# Altium schematic sheet SH_DIM_V1.0.SchDot
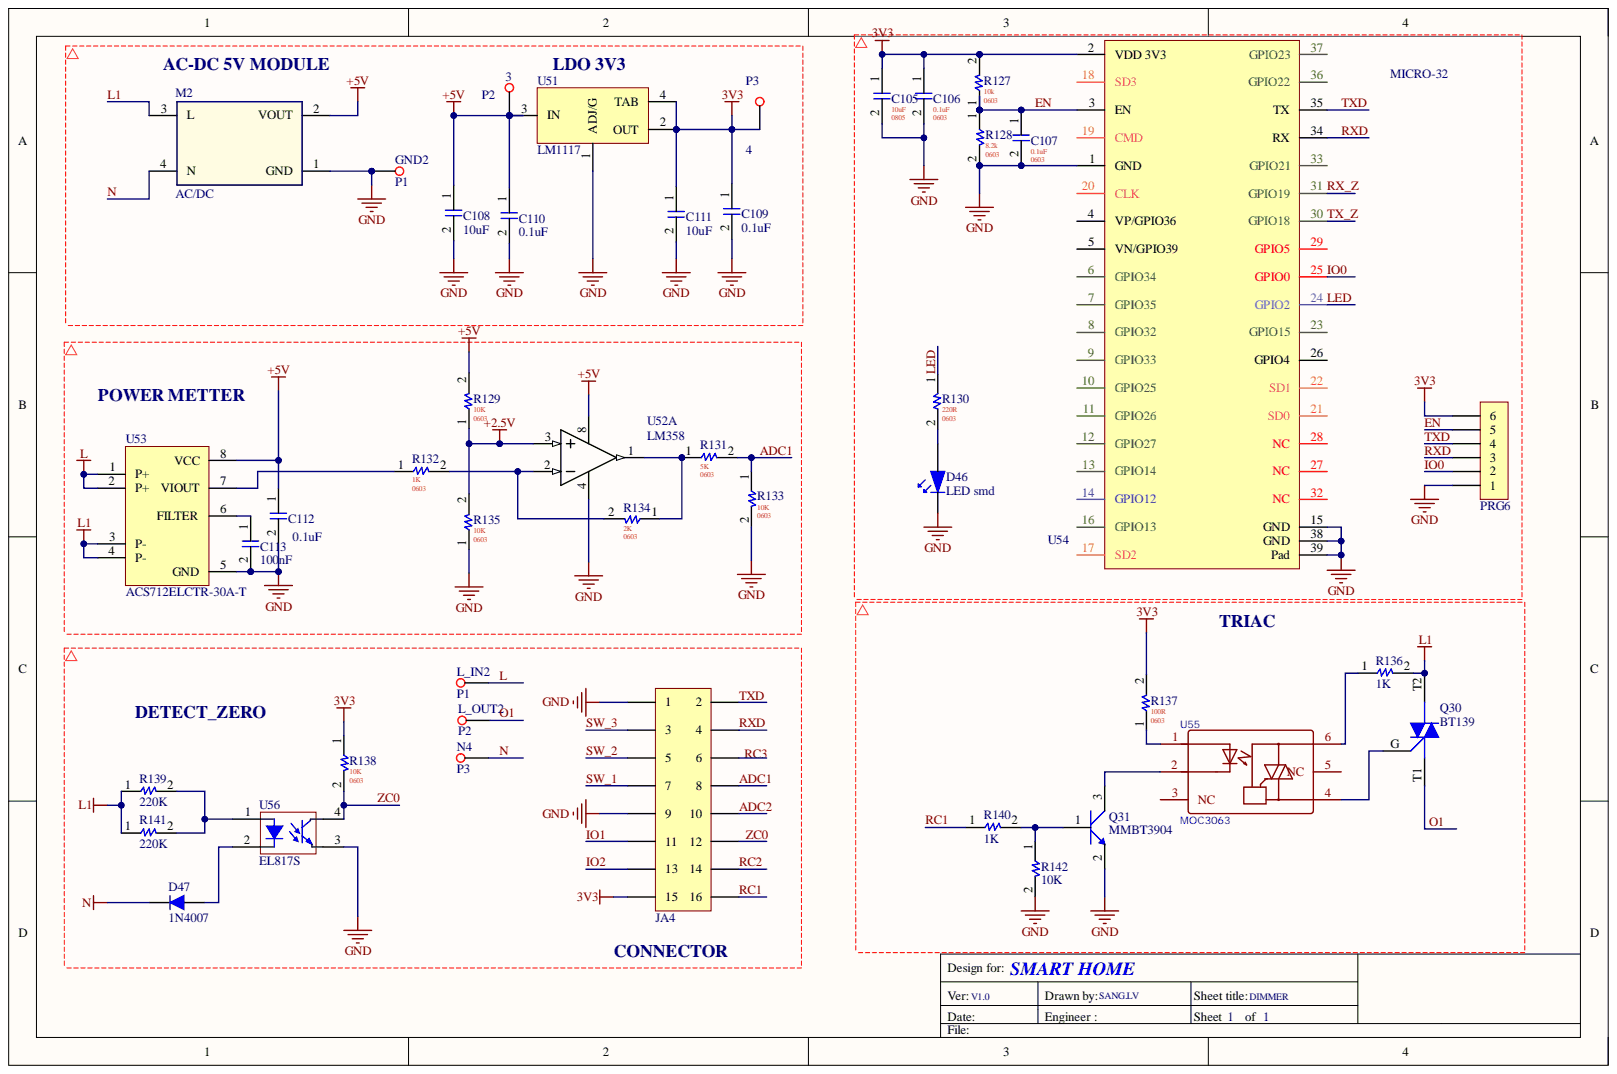
[diagram p0: SH_DIM_V1.0.SchDot]

## Parts
3  P2  [TEXT_POINT]
4  P3  [TEXT_POINT]
C105  10uF  FP: 0805  [0805 C]
C106  0.1uF  FP: 0603  [0603 C]
C107  0.1uF  FP: 0603  [0603 C]
C108  10uF  [0805 C]
C109  0.1uF  [0603 C]
C110  0.1uF  [0603 C]
C111  10uF  [0805 C]
C112  0.1uF  [0603 C]
C113  100nF  [0603 C]
D46  LED smd  [LED0603_1608]
D47  1N4007  [SOD-323]
GND2  P1  [TEXT_POINT]
JA4  rao doi  [T]  (value not drawn)
L_IN2  P1  [P1]
L_OUT2  P2  [P1]
M2  AC/DC  [AC-DC 5V K4H24]
N4  P3  [P1]
PRG6  P6  [P6 - 1.27MM]  (value not drawn)
Q30  BT139  [TO-220]
Q31  MMBT3904  [SOT 23-3]
R127  10k  FP: 0603  [0603 R]
R128  8.2k  FP: 0603  [0603 R]
R129  value 10K  FP: 0603  [0603 R]  (hidden comment: 5K1)
R130  value 220R  FP: 0603  [0603 R]  (hidden comment: 10k)
R131  5K  FP: 0603  [0603 R]
R132  1K  FP: 0603  [0603 R]
R133  10K  FP: 0603  [0603 R]
R134  2K  FP: 0603  [0603 R]
R135  10K  FP: 0603  [0603 R]
R136  1K  [1206 R]
R137  100R  FP: 0603  [0603 R]
R138  value 10K  FP: 0603  [0603 R]  (hidden comment: 1.5K)
R139  220K  [1206 R]
R140  1K  [0603 R]
R141  220K  [1206 R]
R142  10K  [0603 R]
U51  LM1117  [SOT23-4]
U52  LM358  [SOIC 8]  (part A)
U53  ACS712ELCTR-30A-T  [SOIC 8]
U54  MICRO-32  [ESP MICRO 32]
U55  MOC3063  [TO252]
U56  EL817S  [EL357N]

## Nets
3V3: 4.1 C105.1 C106.1 C109.1 C111.1 JA4.15 PRG6.6 R127.2 R137.2 R138.1 U51.2(OUT) U51.4(TAB) U54.2(VDD_3V3)
+2.5V: R129.1 R135.2 U52.3
+5V: 3.1 C108.1 C110.1 C112.1 M2.2(VOUT) R129.2 U51.3(IN) U52.8 U53.8(VCC)
GND: C105.2 C106.2 C107.2 C108.2 C109.2 C110.2 C111.2 C112.2 C113.2 D46.2 GND2.1 JA4.1 JA4.9 M2.1(GND) PRG6.1 Q31.2 R128.2 R133.2 R135.1 R142.2 U51.1(ADJ/G) U52.4 U53.5(GND) U54.1(GND) U54.15(GND) U54.38(GND) U54.39(Pad) U56.3
ADC1: JA4.8 R131.2 R133.1
ADC2: JA4.10
EN: C107.1 PRG6.5 R127.1 R128.1 U54.3(EN)
IO0: PRG6.2 U54.25(GPIO0)
IO1: JA4.11
IO2: JA4.13
L: L_IN2.1 U53.1(P+) U53.2(P+)
L1: M2.3(L) Q30.T2 R136.2 R139.1 R141.1 U53.3(P-) U53.4(P-)
LED: R130.1 U54.24(GPIO2)
N: D47.2 M2.4(N) N4.1
O1: L_OUT2.1 Q30.T1
RC1: JA4.16 R140.1
RC2: JA4.14
RX_Z: U54.31(GPIO19)
RXD: JA4.4 PRG6.3 U54.34(RX)
SW_1: JA4.7
SW_2: JA4.5
SW_3: JA4.3
TX_Z: U54.30(GPIO18)
TXD: JA4.2 PRG6.4 U54.35(TX)
ZC0: JA4.12 R138.2 U56.4
NetC113_1: C113.1 U53.6(FILTER)
NetD46_1: D46.1 R130.2
NetD47_1: D47.1 U56.2
NetQ30_G: Q30.G U55.4
NetQ31_1: Q31.1 R140.2 R142.1
NetQ31_3: Q31.3 U55.2
NetR131_1: R131.1 R134.1 U52.1
NetR132_1: R132.1 U53.7(VIOUT)
NetR132_2: R132.2 R134.2 U52.2
NetR136_1: R136.1 U55.6
NetR137_1: R137.1 U55.1
NetR139_2: R139.2 R141.2 U56.1
RC3:

Not connected: JA4 6; U54 4(VP/GPIO36) 5(VN/GPIO39) 6(GPIO34) 7(GPIO35) 8(GPIO32) 9(GPIO33) 10(GPIO25) 11(GPIO26) 12(GPIO27) 13(GPIO14) 14(GPIO12) 16(GPIO13) 17(SD2) 18(SD3) 19(CMD) 20(CLK) 21(SD0) 22(SD1) 23(GPIO15) 26(GPIO4) 27(NC) 28(NC) 29(GPIO5) 32(NC) 33(GPIO21) 36(GPIO22) 37(GPIO23); U55 3(NC) 5(NC)

## Library data of the parts
3 4 L_OUT2 N4 = P1: Component Kind: Standard; Library Name: Kienlib.SchLib
C105 = 0805 10uF CER: CAP CER 10uF 50V 10% 0805 SMD; VOLTAGE + TOLE: 50V 10%; Component Kind: Standard; ComponentLink1Description: New link; DATE: 04-Mar-21; Library Name: Capacitor.SchLib; PACKAGE: SMD; VALUE: 10uF; CREATOR: MMUOP
C106 C107 = 0603 0.1uF CER: CAP CER 0.1uF 50V 10% 0603 SMD; VOLTAGE + TOLE: 50V 10%; Component Kind: Standard; ComponentLink1Description: New link; DATE: 04-Mar-21; Library Name: Capacitor.SchLib; PACKAGE: SMD; VALUE: 0.1uF; CREATOR: MMUOP
C108-C113 = CAP NOP: Component Kind: Standard; Library Name: Kienlib.SchLib
D47 = Diode schottky: Component Kind: Standard; Library Name: Kienlib.SchLib
JA4 = P2x8: Component Kind: Standard; Library Name: Kienlib.SchLib
Q30 = TRIAC: Component Kind: Standard; Library Name: Kienlib.SchLib
Q31 = TRANS NPN: Component Kind: Standard; Library Name: Kienlib.SchLib
R127 R138 = 0603 10k 1%: 10k 1% 0603 SMD; PN: C98220; Component Kind: Standard; ComponentLink1Description: New link; DATE: 04-Mar-21; Library Name: Res.SchLib; PACKAGE: SMD; VOLTAGE + TOLE: 1%; CREATOR: MMUOP
  R127: VALUE: 10k
R128 = 0603 8.2k 1%: 8.2k 1% 0603 SMD; Component Kind: Standard; ComponentLink1Description: New link; DATE: 04-Mar-21; Library Name: Res.SchLib; PACKAGE: SMD; VALUE: 8.2k; VOLTAGE + TOLE: 1%; CREATOR: MMUOP
R129 = 0603 10k 1%: 10K 1% 0603 SMD; PN: C98220; Component Kind: Standard; ComponentLink1Description: New link; DATE: 04-Mar-21; Library Name: Res.SchLib; PACKAGE: SMD; VOLTAGE + TOLE: 1%; CREATOR: MMUOP
R130 = 0603 10k 1%: 220R 1% 0603 SMD; PN: C98220; Component Kind: Standard; ComponentLink1Description: New link; DATE: 04-Mar-21; Library Name: Res.SchLib; PACKAGE: SMD; VOLTAGE + TOLE: 1%; CREATOR: MMUOP
R131 = 0603 100k 1%: 5K 1% 0603 SMD; Component Kind: Standard; ComponentLink1Description: New link; DATE: 04-Mar-21; Library Name: Res.SchLib; PACKAGE: SMD; VALUE: 5K; VOLTAGE + TOLE: 1%; CREATOR: MMUOP
R132 = 0603 100k 1%: 1K 1% 0603 SMD; Component Kind: Standard; ComponentLink1Description: New link; DATE: 04-Mar-21; Library Name: Res.SchLib; PACKAGE: SMD; VALUE: 1K; VOLTAGE + TOLE: 1%; CREATOR: MMUOP
R133 = 0603 100k 1%: 10K 1% 0603 SMD; Component Kind: Standard; ComponentLink1Description: New link; DATE: 04-Mar-21; Library Name: Res.SchLib; PACKAGE: SMD; VALUE: 10K; VOLTAGE + TOLE: 1%; CREATOR: MMUOP
R134 = 0603 100k 1%: 2K 1% 0603 SMD; Component Kind: Standard; ComponentLink1Description: New link; DATE: 04-Mar-21; Library Name: Res.SchLib; PACKAGE: SMD; VALUE: 2K; VOLTAGE + TOLE: 1%; CREATOR: MMUOP
R135 R137 = 0603 10k 1%: 100R 1% 0603 SMD; PN: C98220; Component Kind: Standard; ComponentLink1Description: New link; DATE: 04-Mar-21; Library Name: Res.SchLib; PACKAGE: SMD; VOLTAGE + TOLE: 1%; CREATOR: MMUOP
  R135: VALUE: 10K
  R137: VALUE: 100R
R136 R139-R142 = Res SMD: Component Kind: Standard; Library Name: Kienlib.SchLib
U52 = OPAMP: Component Kind: Standard; Library Name: Kienlib.SchLib
U53 = ACS71240: ACS712ELCTR-20A  SOIC 8; Component Kind: Standard; ComponentLink1Description: New link; ComponentLink1URL: https://banlinhkiendientu.vn/acs712t/; Library Name: Kienlib.SchLib
U54 = ESP MICRO-32_FIX: Component Kind: Standard; Description: MICRO-32; Library Name: Kienlib.SchLib
U55 = CYMOC3063: Component Kind: Standard
U56 = OPTO: Component Kind: Standard; Library Name: Kienlib.SchLib
D46 = LED smd: Component Kind: Standard; Library Name: Kienlib.SchLib
GND2 L_IN2 = P1: Component Kind: Standard; Library Name: Kienlib.SchLib
M2 = AC/DC: Component Kind: Standard; Library Name: Kienlib.SchLib
PRG6 = P6: Component Kind: Standard; Library Name: Kienlib.SchLib
U51 = LM1117: Component Kind: Standard; Library Name: Kienlib.SchLib
